# Altium schematic sheet GP22_2_PT100.SchDoc(GP22_2_PT100)
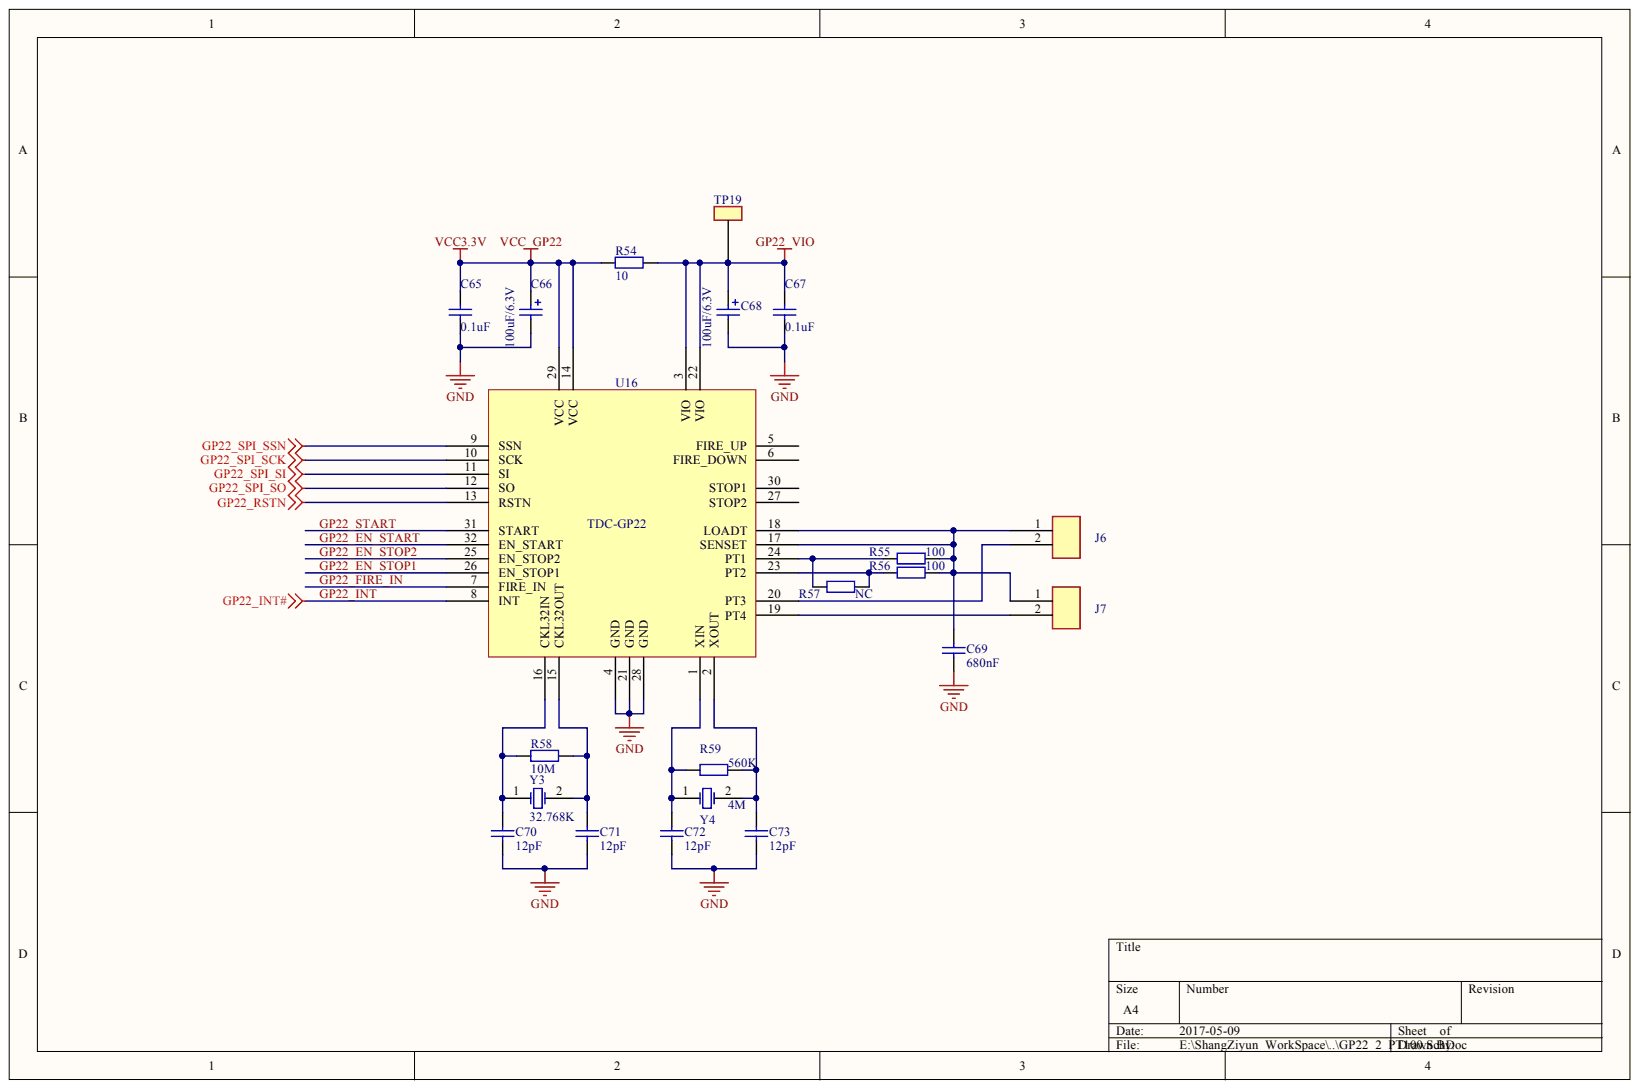
[diagram p0: GP22_2_PT100.SchDoc]

## Parts
C65  value 0.1uF  [0603C]  (hidden comment: Capacitor)
C66  100uF/6.3V  [EIA1206]
C67  value 0.1uF  [0603C]  (hidden comment: Capacitor)
C68  100uF/6.3V  [EIA1206]
C69  value 680nF  [0603C]  (hidden comment: Capacitor)
C70  value 12pF  [0603C]  (hidden comment: Capacitor)
C71  value 12pF  [0603C]  (hidden comment: Capacitor)
C72  value 12pF  [0603C]  (hidden comment: Capacitor)
C73  value 12pF  [0603C]  (hidden comment: Capacitor)
J6  CON2  [XH2.54-2]  (value not drawn)
J7  CON2  [XH2.54-2]  (value not drawn)
R54  value 10  [L0603]  (hidden comment: Resistor)
R55  value 100  [L0603]  (hidden comment: Resistor)
R56  value 100  [L0603]  (hidden comment: Resistor)
R57  value NC  [L0603]  (hidden comment: Resistor)
R58  value 10M  [L0603]  (hidden comment: Resistor)
R59  value 560K  [L0603]  (hidden comment: Resistor)
TP19  TEST POINT_0  [TP]  (value not drawn)
U16  TDC-GP22  [QFN32-0.5]
Y3  value 32.768K  [fc135]  (hidden comment: XTAL)
Y4  value 4M  [HC-49S]  (hidden comment: XTAL)

## Nets
GND: C65.2 C66.2 C67.2 C68.2 C69.1 C70.1 C71.1 C72.1 C73.1 U16.4(GND) U16.21(GND) U16.28(GND)
GP22_RSTN: U16.13(RSTN)
GP22_SPI_SCK: U16.10(SCK)
GP22_SPI_SI: U16.11(SI)
GP22_SPI_SO: U16.12(SO)
GP22_SPI_SSN: U16.9(SSN)
GP22_VIO: C67.1 C68.1 R54.2 TP19.1 U16.3(VIO) U16.22(VIO)
VCC3.3V: C65.1 C66.1 R54.1 U16.14(VCC) U16.29(VCC)
GP22_EN_START: U16.32(EN_START)
GP22_EN_STOP1: U16.26(EN_STOP1)
GP22_EN_STOP2: U16.25(EN_STOP2)
GP22_FIRE_IN: U16.7(FIRE_IN)
GP22_INT: U16.8(INT)
GP22_START: U16.31(START)
NetC69_2: C69.2 J6.1 J7.1 R55.1 R56.2 U16.17(SENSET) U16.18(LOADT)
NetC70_2: C70.2 R58.1 U16.16(CKL32IN) Y3.1
NetC71_2: C71.2 R58.2 U16.15(CKL32OUT) Y3.2
NetC72_2: C72.2 R59.1 U16.1(XIN) Y4.1
NetC73_2: C73.2 R59.2 U16.2(XOUT) Y4.2
NetJ6_2: J6.2 U16.20(PT3)
NetJ7_2: J7.2 U16.19(PT4)
NetR55_2: R55.2 R57.2 U16.24(PT1)
NetR56_1: R56.1 R57.1 U16.23(PT2)

Not connected: U16 5(FIRE_UP) 6(FIRE_DOWN) 27(STOP2) 30(STOP1)

## Library data of the parts
C65 C67 C69-C73 = Cap: Component Kind: Standard; Description: Capacitor; LatestRevisionDate: 17-Jul-2002; LatestRevisionNote: Re-released for DXP Platform.; Library Name: Lib1.SCHLIB; PackageDescription: Radial Cap, Thru-Hole; 2 Leads; 0.3 in Pin Spacing; PackageReference: RAD-0.3; Published: 8-Jun-2000; Signal Integrity: Cap; Simulation: CAP; Publisher: Altium Limited
C66 C68 = Cap pol: Value: 330uF/10V钽电容; Component Kind: Standard; Library Name: LNG.SCHLIB
J6 J7 = CON2: Value: XH2.54-2P; Component Kind: Standard; Library Name: MAINBOARD_Library.SchLib
R54-R59 = Res: Component Kind: Standard; Library Name: Lib1.SCHLIB
Y3 Y4 = XTAL: Crystal Oscillator; Component Kind: Standard; LatestRevisionDate: 17-Jul-2002; LatestRevisionNote: Re-released for DXP Platform.; Library Name: Miscellaneous Devices.IntLib; PackageDescription: Crystal, Thru-Hole; 2 Leads; Body 3.1 x 8.2 mm (Dia.xH); PackageReference: R38; Published: 8-Jun-2000; Publisher: Altium Limited
TP19 = TEST POINT_0: Component Kind: Standard; Library Name: MAINBOARD_Library.SchLib
U16 = TDC-GP22: Component Kind: Standard; Value: TDC-GP22; Library Name: 简配版生物罐.SCHLIB
